# Altium schematic sheet RLSEB153VS.SchDoc
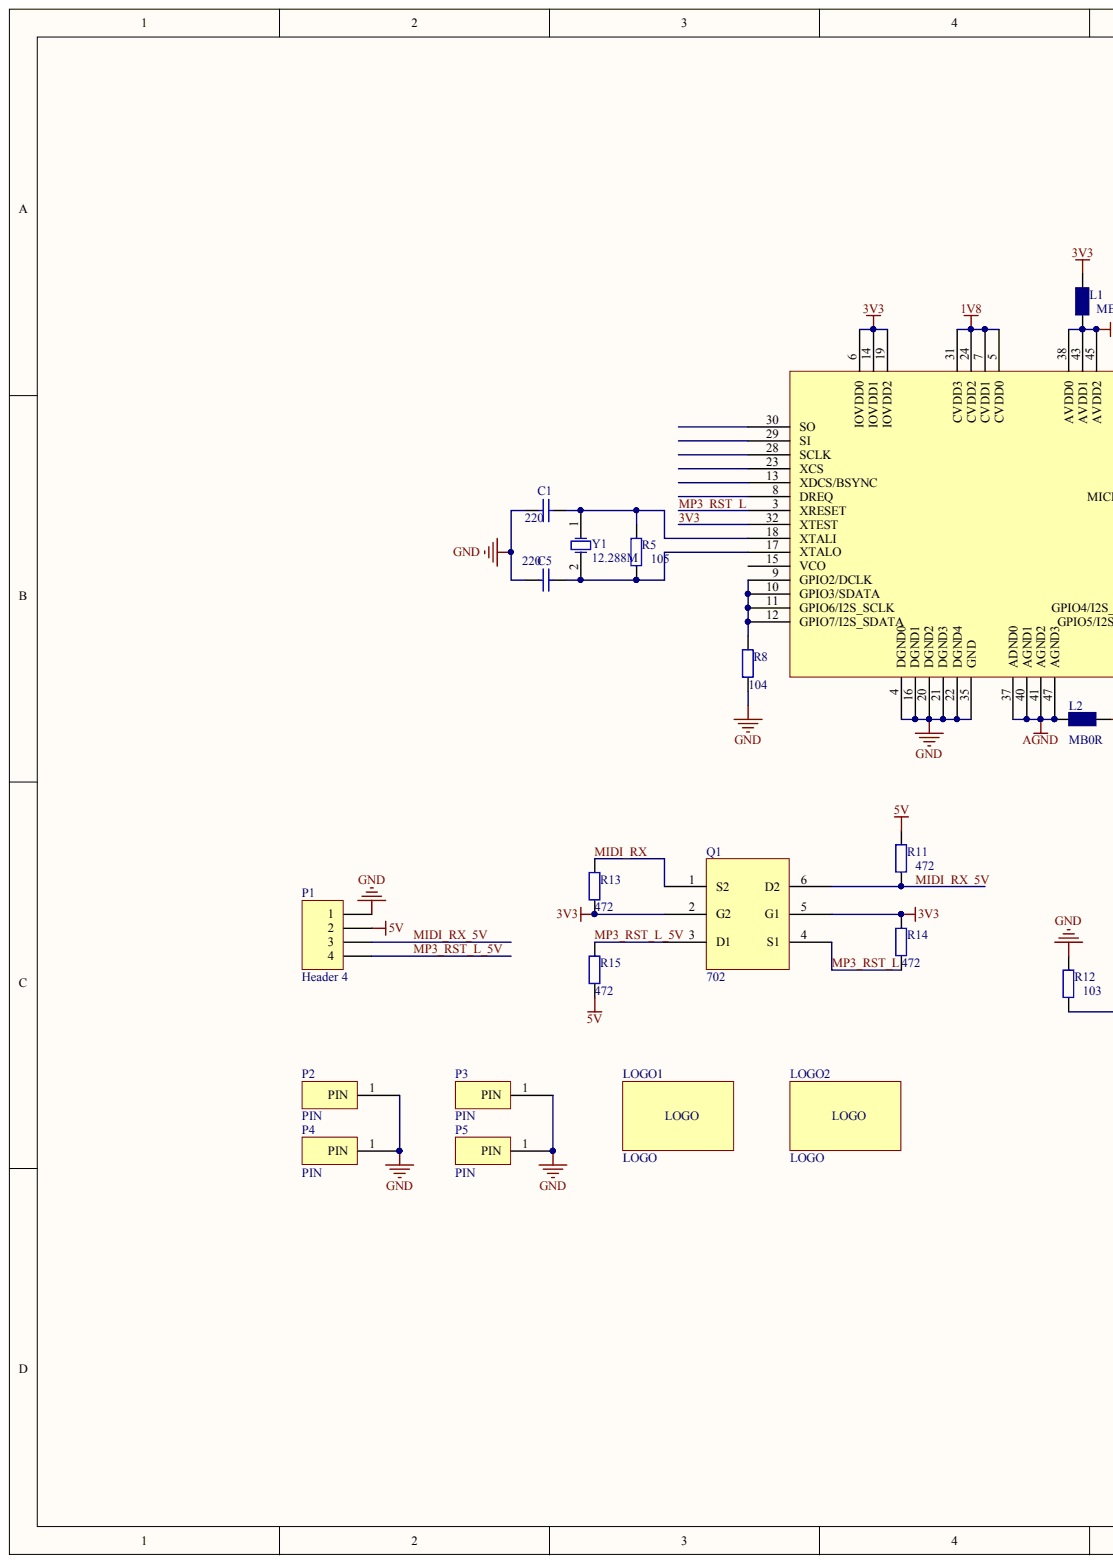
[diagram p0: RLSEB153VS.SchDoc, left]
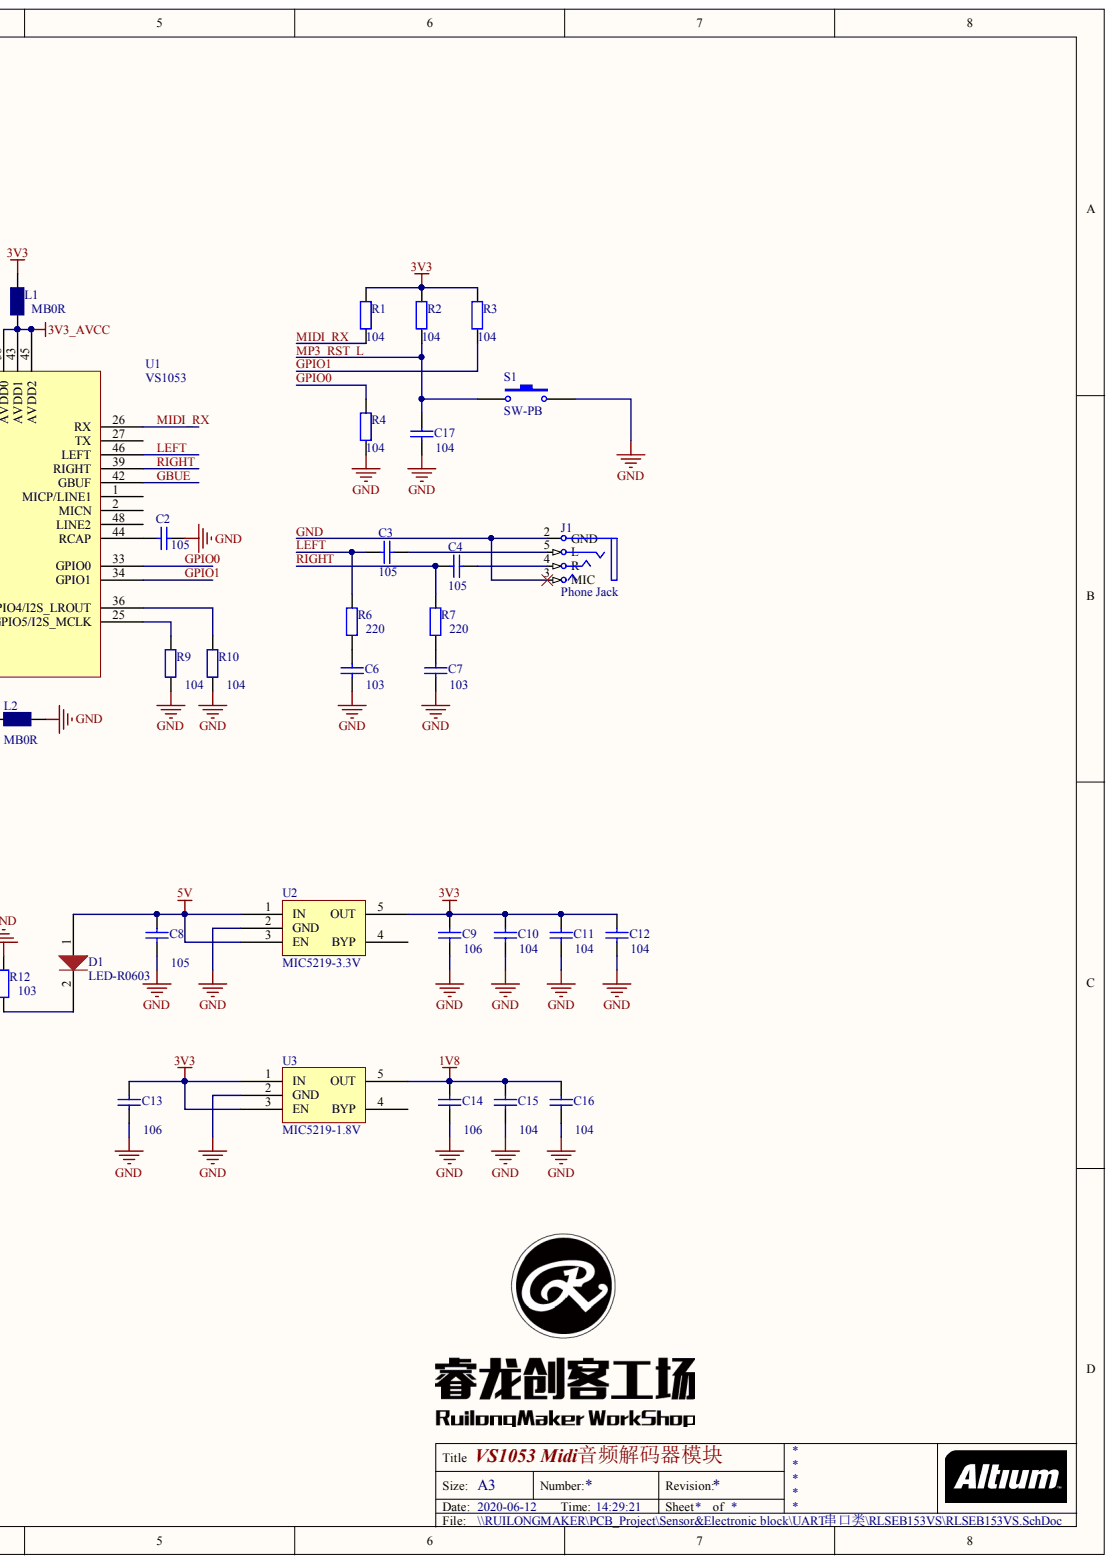
[diagram p1: RLSEB153VS.SchDoc, right]

## Parts
C1  220  [C-0603]
C2  105  [C-0603]
C3  105  [C-0603]
C4  105  [C-0603]
C5  220  [C-0603]
C6  103  [C-0603]
C7  103  [C-0603]
C8  105  [C-0603]
C9  106  [C-0603]
C10  104  [C-0603]
C11  104  [C-0603]
C12  104  [C-0603]
C13  106  [C-0603]
C14  106  [C-0603]
C15  104  [C-0603]
C16  104  [C-0603]
C17  104  [C-0603]
D1  LED-R0603  [LED0603-R]
J1  Phone Jack  [PJ-311D]
L1  MB0R  [L-0603]
L2  MB0R  [L-0603]
LOGO1  LOGO  [LOGO-XIAO-272mils]
LOGO2  LOGO  [oshw]
P1  Header 4  [XH2.54-4P]
P2  PIN  [M3安装孔]
P3  PIN  [M3安装孔]
P4  PIN  [M3安装孔]
P5  PIN  [M3安装孔]
Q1  702  [SOT-363]
R1  104  [R-0603]
R2  104  [R-0603]
R3  104  [R-0603]
R4  104  [R-0603]
R5  105  [R-0603]
R6  220  [R-0603]
R7  220  [R-0603]
R8  104  [R-0603]
R9  104  [R-0603]  (value not drawn)
R10  104  [R-0603]
R11  472  [r-0603]
R12  103  [R-0603]
R13  472  [r-0603]
R14  472  [r-0603]
R15  472  [r-0603]
S1  SW-PB  [SW-3.5*4.7-TS-015A]
U1  VS1053  [LQFP-48]
U2  MIC5219-3.3V  [SOT23-5]
U3  MIC5219-1.8V  [SOT23-5]
Y1  12.288M  [XTAL-3225]

## Nets
1V8: C14.2 C15.2 C16.2 U1.5(CVDD0) U1.7(CVDD1) U1.24(CVDD2) U1.31(CVDD3) U3.5(OUT)
3V3_AVCC: L1.1 U1.38(AVDD0) U1.43(AVDD1) U1.45(AVDD2)
5V: C8.2 D1.1 P1.2 R11.2 R15.1 U2.1(IN) U2.3(EN)
AGND: L2.2 U1.37(ADND0) U1.40(AGND1) U1.41(AGND2) U1.47(AGND3)
3V3: C9.2 C10.2 C11.2 C12.2 C13.2 L1.2 Q1.2(G2) Q1.5(G1) R1.1 R2.1 R3.1 R13.1 R14.2 U1.6(IOVDD0) U1.14(IOVDD1) U1.19(IOVDD2) U1.32(XTEST) U2.5(OUT) U3.1(IN) U3.3(EN)
GBUE: U1.42(GBUF)
GND: C1.1 C2.1 C5.1 C6.1 C7.1 C8.1 C9.1 C10.1 C11.1 C12.1 C13.1 C14.1 C15.1 C16.1 C17.1 J1.2(GND) J1.3(MIC) L2.1 P1.1 P2.1 P3.1 P4.1 P5.1 R4.1 R8.2 R9.2 R10.2 R12.1 S1.2 U1.4(DGND0) U1.16(DGND1) U1.20(DGND2) U1.21(DGND3) U1.22(DGND4) U1.35(GND) U2.2(GND) U3.2(GND)
GPIO0: R4.2 U1.33(GPIO0)
GPIO1: R3.2 U1.34(GPIO1)
LEFT: C3.2 R6.1 U1.46(LEFT)
MIDI_RX: Q1.1(S2) R1.2 R13.2 U1.26(RX)
MIDI_RX_5V: P1.3 Q1.6(D2) R11.1
MP3_RST_L: C17.2 Q1.4(S1) R2.2 R14.1 S1.1 U1.3(XRESET)
MP3_RST_L_5V: P1.4 Q1.3(D1) R15.2
RIGHT: C4.2 R7.1 U1.39(RIGHT)
NetC1_2: C1.2 R5.1 U1.18(XTALI) Y1.1
NetC2_2: C2.2 U1.44(RCAP)
NetC3_1: C3.1 J1.5(L)
NetC4_1: C4.1 J1.4(R)
NetC5_2: C5.2 R5.2 U1.17(XTALO) Y1.2
NetC6_2: C6.2 R6.2
NetC7_2: C7.2 R7.2
NetD1_2: D1.2 R12.2
NetR8_1: R8.1 U1.9(GPIO2/DCLK) U1.10(GPIO3/SDATA) U1.11(GPIO6/I2S_SCLK) U1.12(GPIO7/I2S_SDATA)
NetR9_1: R9.1 U1.25(GPIO5/I2S_MCLK)
NetR10_1: R10.1 U1.36(GPIO4/I2S_LROUT)

Not connected: U1 1(MICP/LINE1) 2(MICN) 8(DREQ) 13(XDCS/BSYNC) 15(VCO) 23(XCS) 27(TX) 28(SCLK) 29(SI) 30(SO) 48(LINE2); U2 4(BYP); U3 4(BYP)

## Library data of the parts
C1 C2 C5-C12 C14-C16 = Cap: Capacitor; Component Kind: Standard; LatestRevisionDate: 17-Jul-2002; LatestRevisionNote: Re-released for DXP Platform.; Library Name: Miscellaneous Devices.IntLib; PackageReference: RAD-0.3; Published: 8-Jun-2000; Signal Integrity: Cap; Simulation: CAP; Publisher: Altium Limited
  C1 C5: Value: 220
  C2: PackageDescription: Radial Cap, Thru-Hole; 2 Leads; 0.3 in Pin Spacing; Value: 105
  C6 C7: Value: 103
  C8: Value: 105
  C9 C14: Value: 106
  C10-C12 C15 C16: Value: 104
C3 C4 C17 = Cap: Capacitor; Value: 103; Component Kind: Standard; LatestRevisionDate: 17-Jul-2002; LatestRevisionNote: Re-released for DXP Platform.; Library Name: Miscellaneous Devices.IntLib; PackageReference: RAD-0.3; Published: 8-Jun-2000; Signal Integrity: Cap; Simulation: CAP; Publisher: Altium Limited
C13 = Cap: Capacitor; Value: 104; Component Kind: Standard; LatestRevisionDate: 17-Jul-2002; LatestRevisionNote: Re-released for DXP Platform.; Library Name: Miscellaneous Devices.IntLib; PackageReference: RAD-0.3; Published: 8-Jun-2000; Signal Integrity: Cap; Simulation: CAP; Publisher: Altium Limited
D1 = LED-R: Component Kind: Standard; Library Name: 需用原件汇总.SchLib
L1 L2 = WE-CBF_0805: Component Kind: Standard; Library Name: wuerth-elektronik
P1 = Header 4: Header, 4-Pin; Component Kind: Standard; LatestRevisionDate: 17-Jul-2002; LatestRevisionNote: Re-released for DXP Platform.; Library Name: Miscellaneous Connectors.IntLib; Signal Integrity: Connector; Publisher: Altium Limited
Q1 = L2N7002DW1T1G: Component Kind: Standard; Library Name: 需用原件汇总.SchLib
R1-R11 R13-R15 = Res2: Resistor; Component Kind: Standard; LatestRevisionDate: 17-Jul-2002; LatestRevisionNote: Re-released for DXP Platform.; Library Name: Miscellaneous Devices.IntLib; PackageDescription: Axial Device, Thru-Hole; 2 Leads; 0.4 in Pin Spacing; PackageReference: AXIAL-0.4; Published: 8-Jun-2000; Signal Integrity: Res; Simulation: RESISTOR; Publisher: Altium Limited
  R1-R4 R8-R10: Value: 104
  R5: Value: 105
  R6 R7: Value: 220
  R11 R13-R15: Value: 472
R12 = Res2: Resistor; Value: 220; Component Kind: Standard; LatestRevisionDate: 17-Jul-2002; LatestRevisionNote: Re-released for DXP Platform.; Library Name: Miscellaneous Devices.IntLib; PackageDescription: Axial Device, Thru-Hole; 2 Leads; 0.4 in Pin Spacing; PackageReference: AXIAL-0.4; Published: 8-Jun-2000; Signal Integrity: Res; Simulation: RESISTOR; Publisher: Altium Limited
S1 = SW-PB: Switch; Component Kind: Standard; LatestRevisionDate: 17-Jul-2002; LatestRevisionNote: Re-released for DXP Platform.; Library Name: Miscellaneous Devices.IntLib; PackageDescription: Switch; 2 Leads; PackageReference: SPST-2; Published: 24-Mar-1999; Publisher: Altium Limited
U2 U3 = MIC5219-5.0/3.3/1.8V: Component Kind: Standard; Library Name: 需用原件汇总.SchLib
Y1 = XTAL: Crystal Oscillator; Component Kind: Standard; LatestRevisionDate: 17-Jul-2002; LatestRevisionNote: Re-released for DXP Platform.; Library Name: Miscellaneous Devices.IntLib; PackageDescription: Crystal, Thru-Hole; 2 Leads; Body 3.1 x 8.2 mm (Dia.xH); PackageReference: R38; Published: 8-Jun-2000; Publisher: Altium Limited
J1 = Phone Jack: Component Kind: Standard; Description: Phone Jack; Library Name: 需用原件汇总.SchLib
LOGO1 LOGO2 = LOGO: Component Kind: Standard; Library Name: 需用原件汇总.SchLib
P2-P5 = PIN: Component Kind: Standard; Library Name: 需用原件汇总.SchLib
U1 = VS1053: Component Kind: Standard; Library Name: 需用原件汇总.SchLib
